# Altium schematic sheet iWatch-v2.0.SchDoc
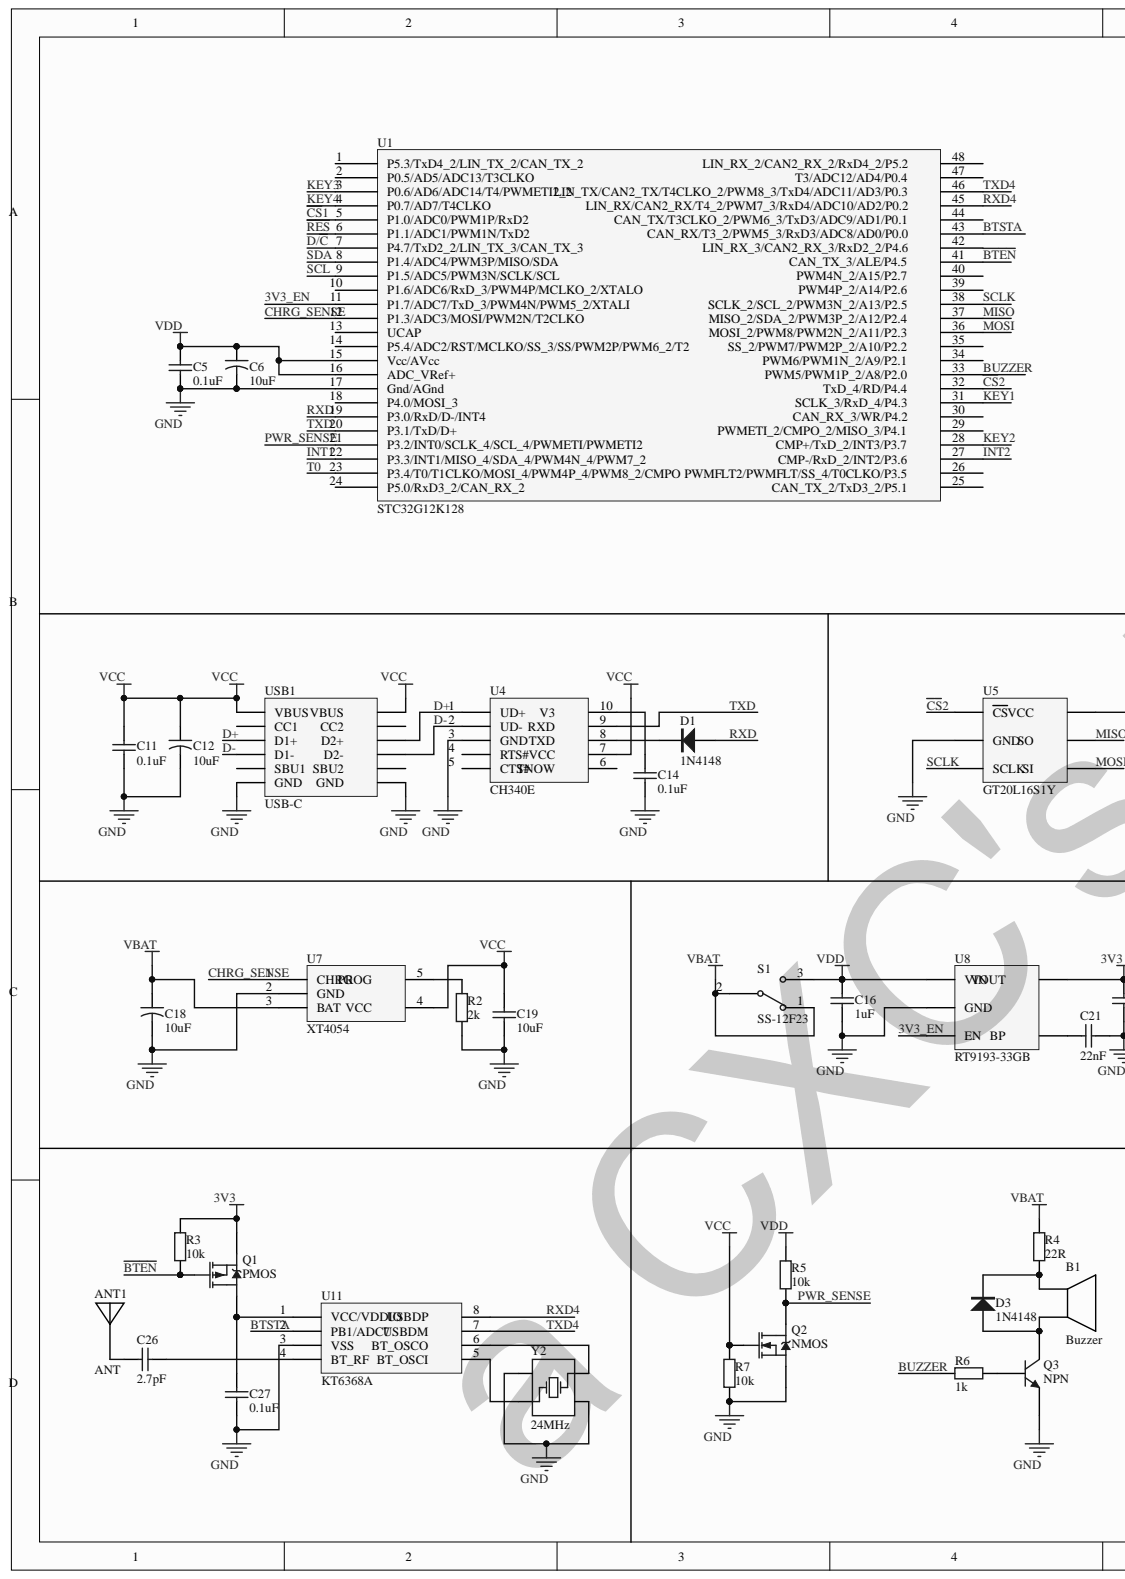
[diagram p0: iWatch-v2.0.SchDoc, left]
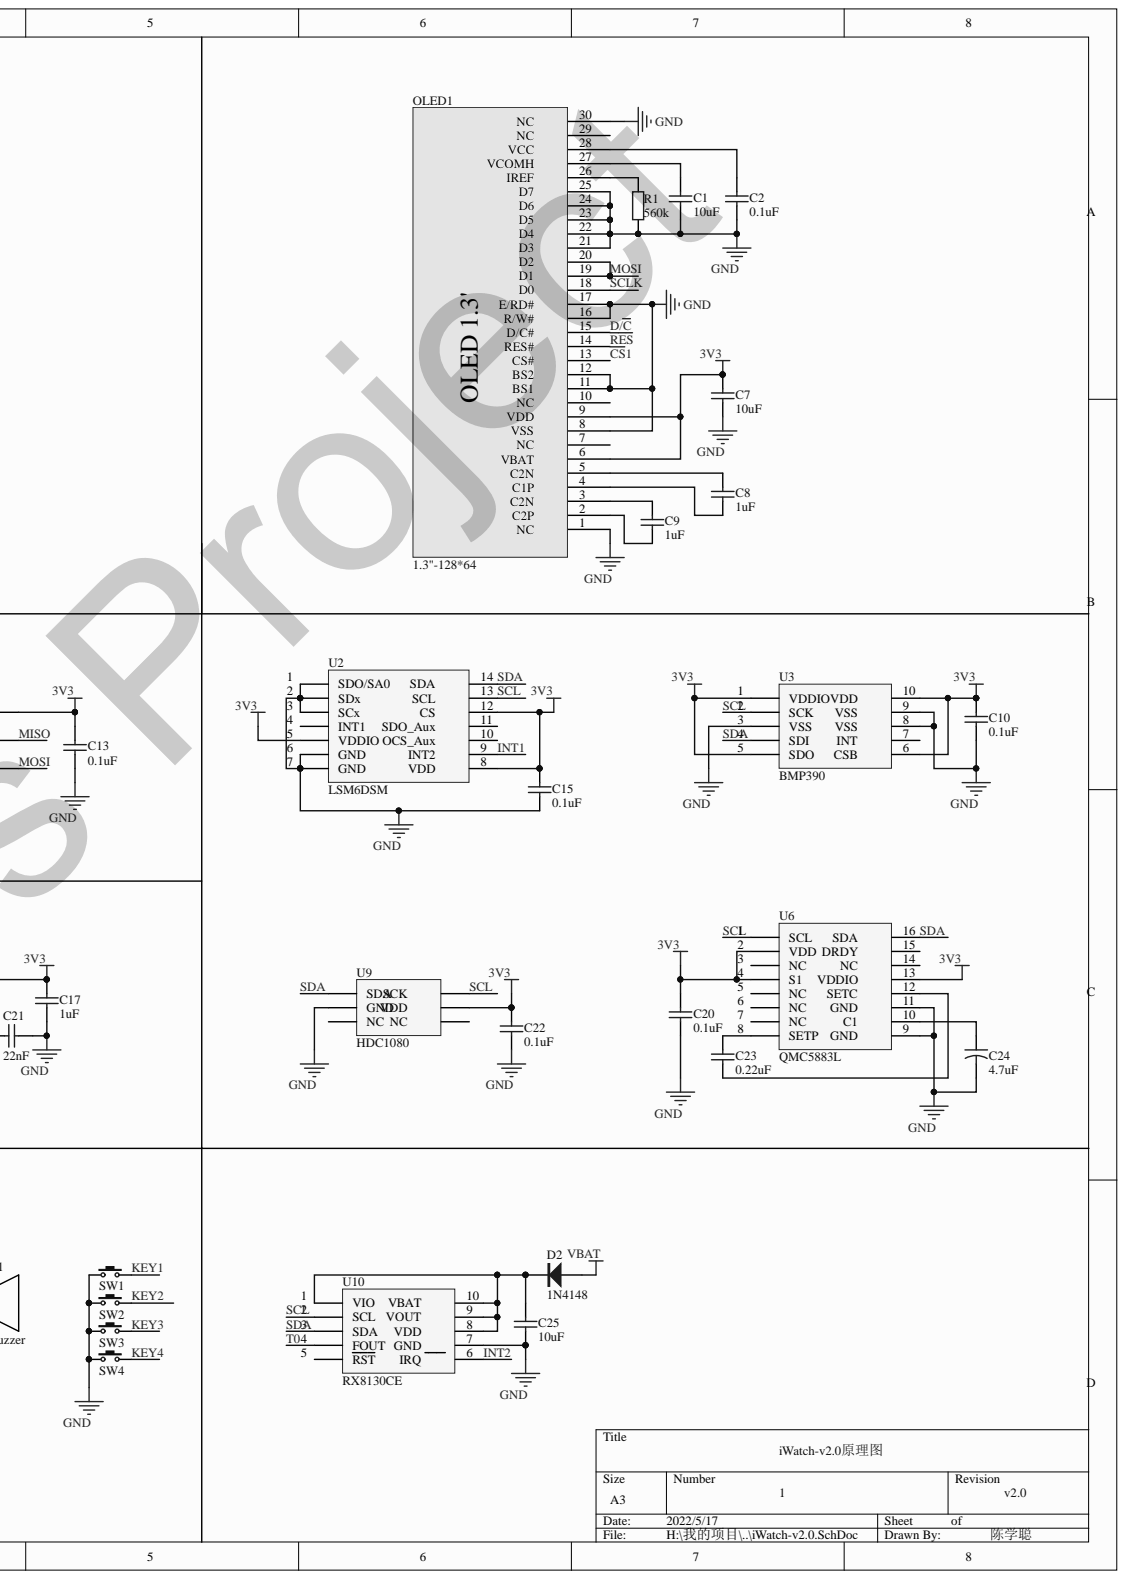
[diagram p1: iWatch-v2.0.SchDoc, right]

## Parts
ANT1  ANT  [2.4GANT-3216]
B1  Buzzer  [SMD402]
C1  10uF  [C-0603-M]
C2  0.1uF  [C-0603-M]
C5  0.1uF  [C-0603-M]
C6  10uF  [C-钽电容-3216-N]
C7  10uF  [C-0603-M]
C8  1uF  [C-0603-M]
C9  1uF  [C-0603-M]
C10  0.1uF  [C-0603-M]
C11  0.1uF  [C-0603-M]
C12  10uF  [C-钽电容-3216-N]
C13  0.1uF  [C-0603-M]
C14  0.1uF  [C-0603-M]
C15  0.1uF  [C-0603-M]
C16  1uF  [C-0603-M]
C17  1uF  [C-0603-M]
C18  10uF  [C-钽电容-3216-N]
C19  10uF  [C-0603-M]
C20  0.1uF  [C-0603-M]
C21  22nF  [C-0603-M]
C22  0.1uF  [C-0603-M]
C23  0.22uF  value 0.1uF  [C-0603-M]
C24  4.7uF  [C-钽电容-3216-N]
C25  10uF  [C-0603-M]
C26  2.7pF  [C-0603-M]
C27  0.1uF  [C-0603-M]
D1  1N4148  [SOD-323]
D2  1N4148  [SOD-323]
D3  1N4148  [SOD-323]
OLED1  1.3"-128*64  [OLED-1.3-90 - duplicate]
Q1  PMOS  [SOT-23]
Q2  NMOS  [SOT-23]
Q3  NPN  [SOT-23]
R1  560k  [R-0603-M]
R2  2k  [R-0603-M]
R3  10k  [R-0603-M]
R4  22R  [R-0603-M]
R5  10k  [R-0603-M]
R6  1k  [R-0603-M]
R7  10k  [R-0603-M]
S1  SS-12F23  [MK-12C02]
SW1  Button  [TSW SMD-2*4*3.5]  (value not drawn)
SW2  Button  [TSW SMD-2*4*3.5]  (value not drawn)
SW3  Button  [TSW SMD-2*4*3.5]  (value not drawn)
SW4  Button  [TSW SMD-2*4*3.5]  (value not drawn)
U1  STC32G12K128  [LQFP48 7X7_L]
U2  LSM6DSM  [LGA-14L]
U3  BMP390  [LGA-10 FOR BMP390]
U4  CH340E  [MSOP10]
U5  GT20L16S1Y  [SOT23-6L]
U6  QMC5883L  [QFN16 3X3]
U7  XT4054  [SOT23-5]
U8  RT9193-33GB  [SOT23-5]
U9  HDC1080  [DFN-6  for HDC1080]
U10  RX8130CE  [RX8130CE]
U11  KT6368A  [SOP8_L]
USB1  USB-C  [USB-C-SMD_16P]
Y2  value 24MHz  [OSC 2025-4P]  (hidden comment: XTAL_1)

## Nets
3V3: C7.1 C10.1 C13.1 C15.1 C17.1 C20.1 C22.1 OLED1.6 OLED1.9 Q1.2 R3.1 U2.5 U2.8 U2.12 U3.1 U3.5 U3.6 U3.10 U5.4 U6.2 U6.4 U6.13 U8.5 U9.5
GND: C1.2 C2.2 C5.1 C6.2 C7.2 C10.2 C11.1 C12.2 C13.2 C14.2 C15.2 C16.2 C17.2 C18.2 C19.1 C20.2 C21.2 C22.2 C24.2 C25.2 C27.2 OLED1.1 OLED1.8 OLED1.11 OLED1.12 OLED1.16 OLED1.17 OLED1.21 OLED1.22 OLED1.23 OLED1.24 OLED1.25 OLED1.30 Q2.2 Q3.2 R1.1 R2.1 R7.1 SW1.2 SW2.2 SW3.1 SW4.1 U1.17 U2.1 U2.2 U2.3 U2.6 U2.7 U3.3 U3.8 U3.9 U4.3 U5.2 U6.9 U6.11 U7.2 U8.2 U9.2 U10.7 U11.3 USB1.A1B12 USB1.B1A12 Y2.2 Y2.4
VBAT: C18.1 D2.1 R4.2 S1.1 S1.2 U7.3
VCC: C11.2 C12.1 C19.2 Q2.1 R7.2 U4.7 U7.4 USB1.A4B9 USB1.B4A9
VDD: C5.2 C6.1 C16.1 R5.2 S1.3 U1.15 U1.16 U8.1
3V3_EN: U1.11 U8.3
~{BTEN}: Q1.1 R3.2 U1.41
BTSTA: U1.43 U11.2
BUZZER: R6.2 U1.33
~{CS}1: OLED1.13 U1.5
~{CS}2: U1.32 U5.3
CHRG_SENSE: U1.12 U7.1
D+: U4.1 USB1.A6 USB1.B6
D-: U4.2 USB1.A7 USB1.B7
D/~{C}: OLED1.15 U1.7
INT1: U1.22 U2.9
INT2: U1.27 U10.6
KEY1: SW1.1 U1.31
KEY2: SW2.1 U1.28
KEY3: SW3.2 U1.3
KEY4: SW4.2 U1.4
MISO: U1.37 U5.5
MOSI: OLED1.19 OLED1.20 U1.36 U5.6
PWR_SENSE: Q2.3 R5.1 U1.21
~{RES}: OLED1.14 U1.6
RXD: D1.1 U1.19
RXD4: U1.45 U11.8
SCL: U1.9 U2.13 U3.2 U6.1 U9.6 U10.2
SCLK: OLED1.18 U1.38 U5.1
SDA: U1.8 U2.14 U3.4 U6.16 U9.1 U10.3
T0: U1.23 U10.4
TXD: U1.20 U4.9
TXD4: U1.46 U11.7
NetANT1_1: ANT1.1 C26.1
NetB1_1: B1.1 D3.2 R4.1
NetB1_2: B1.2 D3.1 Q3.3
NetC1_1: C1.1 OLED1.27
NetC2_1: C2.1 OLED1.28
NetC8_1: C8.1 OLED1.5
NetC8_2: C8.2 OLED1.4
NetC9_1: C9.1 OLED1.3
NetC9_2: C9.2 OLED1.2
NetC14_1: C14.1 U4.10
NetC21_1: C21.1 U8.4
NetC23_1: C23.1 U6.8
NetC23_2: C23.2 U6.12
NetC24_1: C24.1 U6.10
NetC25_1: C25.1 D2.2 U10.1 U10.8 U10.9 U10.10
NetC26_2: C26.2 U11.4
NetC27_1: C27.1 Q1.3 U11.1
NetD1_2: D1.2 U4.8
NetOLED1_26: OLED1.26 R1.2
NetQ3_1: Q3.1 R6.1
NetR2_2: R2.2 U7.5
NetU11_5: U11.5 Y2.1
NetU11_6: U11.6 Y2.3

Not connected: OLED1 7 10 29; U1 1 2 10 13 14 18 24 25 26 29 30 34 35 39 40 42 44 47 48; U2 4 10 11; U3 7; U4 4 5 6; U6 3 5 6 7 14 15; U9 3 4; U10 5; USB1 A5 A8 B5 B8

## Library data of the parts
ANT1 = ANT: PCB板载2.4G天线; BOM名称: PCB板载天线; 版权归属: 封装库来自淘宝 ID：黄晶宝石; Component Kind: Standard; 技术支持，联系售后: https://shop463601444.taobao.com; Library Name: cxc’s Integrated_Library.IntLib
B1 = Buzzer: 蜂鸣器; Component Kind: Standard; Library Name: cxc’s Integrated_Library.IntLib
C1 C7-C9 C16 C17 C19 C21 C25 C26 = Cap: 无极性电容; Value: 0.1uF; Component Kind: Standard; LatestRevisionDate: 17-Jul-2002; LatestRevisionNote: Re-released for DXP Platform.; PackageDescription: Radial Cap, Thru-Hole; 2 Leads; 0.3 in Pin Spacing; PackageReference: RAD-0.3; Published: 8-Jun-2000; Library Name: cxc's Schlib.SchLib; Publisher: Altium Limited
C2 C5 C10 C11 C13-C15 C20 C22 C23 C27 = Cap: 无极性电容; Component Kind: Standard; LatestRevisionDate: 17-Jul-2002; LatestRevisionNote: Re-released for DXP Platform.; PackageDescription: Radial Cap, Thru-Hole; 2 Leads; 0.3 in Pin Spacing; PackageReference: RAD-0.3; Published: 8-Jun-2000; Library Name: cxc's Schlib.SchLib; Publisher: Altium Limited
  C2 C5 C10 C11 C13-C15 C20 C22 C27: Value: 0.1uF
C6 C12 C18 = Cap_1: 有极性电容; Component Kind: Standard; PackageDescription: Capacitor; 2 Leads; PackageReference: CAPR5-4X5; Published: 23-Sep-2002; Value: 10uF; Library Name: cxc's Schlib.SchLib; Publisher: Altium Limited
C24 = Cap_1: 有极性电容; Value: 10uF; Component Kind: Standard; PackageDescription: Capacitor; 2 Leads; PackageReference: CAPR5-4X5; Published: 23-Sep-2002; Library Name: cxc's Schlib.SchLib; Publisher: Altium Limited
D1-D3 = 1N4148: 高速开关二极管; 版权归属: 源创客; BOM名称: 高速开关二极管; Component Kind: Standard; 学习资源: www.ooocke.com; Library Name: cxc’s Integrated_Library.IntLib
OLED1 = OLED-1.3: OLED12864屏幕; Component Kind: Standard; Library Name: cxc’s Integrated_Library.IntLib
Q1 = PMOS: P沟道场效应管; 版权归属: 源创客; BOM名称: P沟道场效应管; Component Kind: Standard; 学习资源: www.ooocke.com; Library Name: cxc’s Integrated_Library.IntLib
Q2 = NMOS: N沟道场效应管; 版权归属: 源创客; BOM名称: N沟道场效应管; Component Kind: Standard; 学习资源: www.ooocke.com; Library Name: cxc’s Integrated_Library.IntLib
Q3 = NPN: NPN型三极管; Code_JEDEC: TO-226-AA; Component Kind: Standard; LatestRevisionDate: 17-Jul-2002; LatestRevisionNote: Re-released for DXP Platform.; PackageDescription: TO, Flat Index; 3 In-Line, Axial Leads; Body Dia. 4.8mm; Leads 0.55 x 0.51 mm (max); PackageReference: TO-226-AA; Published: 8-Jun-2000; Library Name: cxc’s Integrated_Library.IntLib; Publisher: Altium Limited
R1-R5 R7 = Resistor: 电阻; Value: 1k; Component Kind: Standard; LatestRevisionDate: 17-Jul-2002; LatestRevisionNote: Re-released for DXP Platform.; PackageDescription: Axial Device, Thru-Hole; 2 Leads; 0.4 in Pin Spacing; PackageReference: AXIAL-0.4; Published: 8-Jun-2000; Library Name: cxc's Schlib.SchLib; Publisher: Altium Limited
R6 = Resistor: 电阻; Component Kind: Standard; LatestRevisionDate: 17-Jul-2002; LatestRevisionNote: Re-released for DXP Platform.; PackageDescription: Axial Device, Thru-Hole; 2 Leads; 0.4 in Pin Spacing; PackageReference: AXIAL-0.4; Published: 8-Jun-2000; Value: 1k; Library Name: cxc's Schlib.SchLib; Publisher: Altium Limited
S1 = SW SPDT: 单刀双掷开关; BOM名称: 波动开关; 版权归属: 源创客; Component Kind: Standard; 学习资源: www.ooocke.com; Library Name: cxc’s Integrated_Library.IntLib
SW1-SW4 = Button: 轻触开关; BOM名称: 3x6轻触开关; 版权归属: 源创客; Component Kind: Standard; 学习资源: www.ooocke.com; Library Name: cxc’s Integrated_Library.IntLib
U1 = STC32G12K128_LQFP48: 增强型51单片机; Component Kind: Standard; Library Name: cxc’s Integrated_Library.IntLib
U2 = LSM6DSM: always-on 3D accelerometer and 3D gyroscope; Component Kind: Standard; Library Name: cxc’s Integrated_Library.IntLib
U3 = BMP390: 博世高精度气压传感器; Component Kind: Standard; Library Name: cxc’s Integrated_Library.IntLib
U4 = CH340E: USB转串口，免外部晶振，超小封装; Component Kind: Standard; Library Name: cxc’s Integrated_Library.IntLib
U5 = GT20L16S1Y: 字库芯片; Component Kind: Standard; Library Name: cxc’s Integrated_Library.IntLib
U6 = QMC5883L: 高精度三轴磁力计; Component Kind: Standard; Library Name: cxc’s Integrated_Library.IntLib
U7 = XT4054: 锂电池充电ic; Component Kind: Standard; Library Name: cxc’s Integrated_Library.IntLib
U8 = RT9193-33GB: LDO-3.3v; Component Kind: Standard; Library Name: cxc’s Integrated_Library.IntLib
U9 = HDC1080: TI/温湿度传感器; Component Kind: Standard; Library Name: cxc’s Integrated_Library.IntLib
U10 = RX8130CE: 内置晶振的RTC; Component Kind: Standard; Library Name: cxc’s Integrated_Library.IntLib
U11 = KT6368A: 蓝牙5.1双模的纯数据芯片,同时支持SPP 和BLE; Component Kind: Standard; Library Name: cxc’s Integrated_Library.IntLib
USB1 = USB-C-SMD_16P: USB-C型母接口; 卖家免费查找封装，联系售后: 唯一出售店铺网址https://shop463601444.taobao.com; 封装库来自淘宝卖家 ID：黄晶宝石: 其他店铺购入均为盗版假冒封装库，请立即申请退款，本店3~10元现金奖励; BOM名称: USB-C型母接口; Component Kind: Standard; Library Name: cxc’s Integrated_Library.IntLib
Y2 = XTAL_1: 4脚晶振; Component Kind: Standard; LatestRevisionDate: 17-Jul-2002; LatestRevisionNote: Re-released for DXP Platform.; PackageDescription: Crystal, Thru-Hole; 2 Leads; Body 3.1 x 8.2 mm (Dia.xH); PackageReference: R38; Published: 8-Jun-2000; Library Name: cxc's Schlib.SchLib; Publisher: Altium Limited
